# Altium schematic sheet 07 - BANK 34 SWITCHES, LEDs.SchDoc
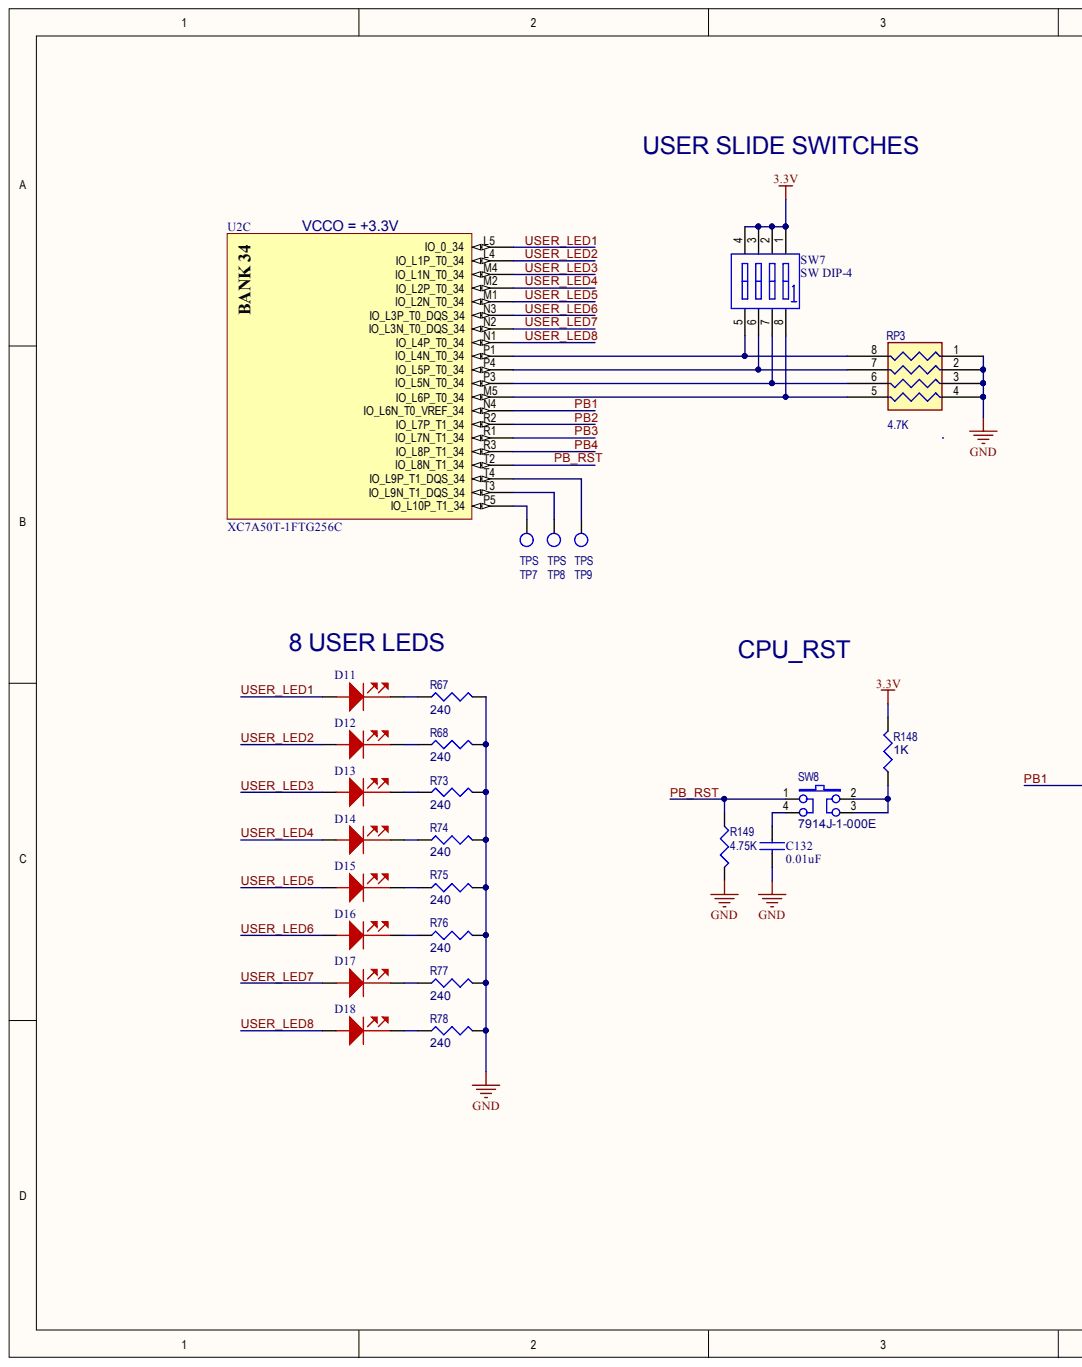
[diagram p0: 07 - BANK 34 SWITCHES, LEDs.SchDoc, left]
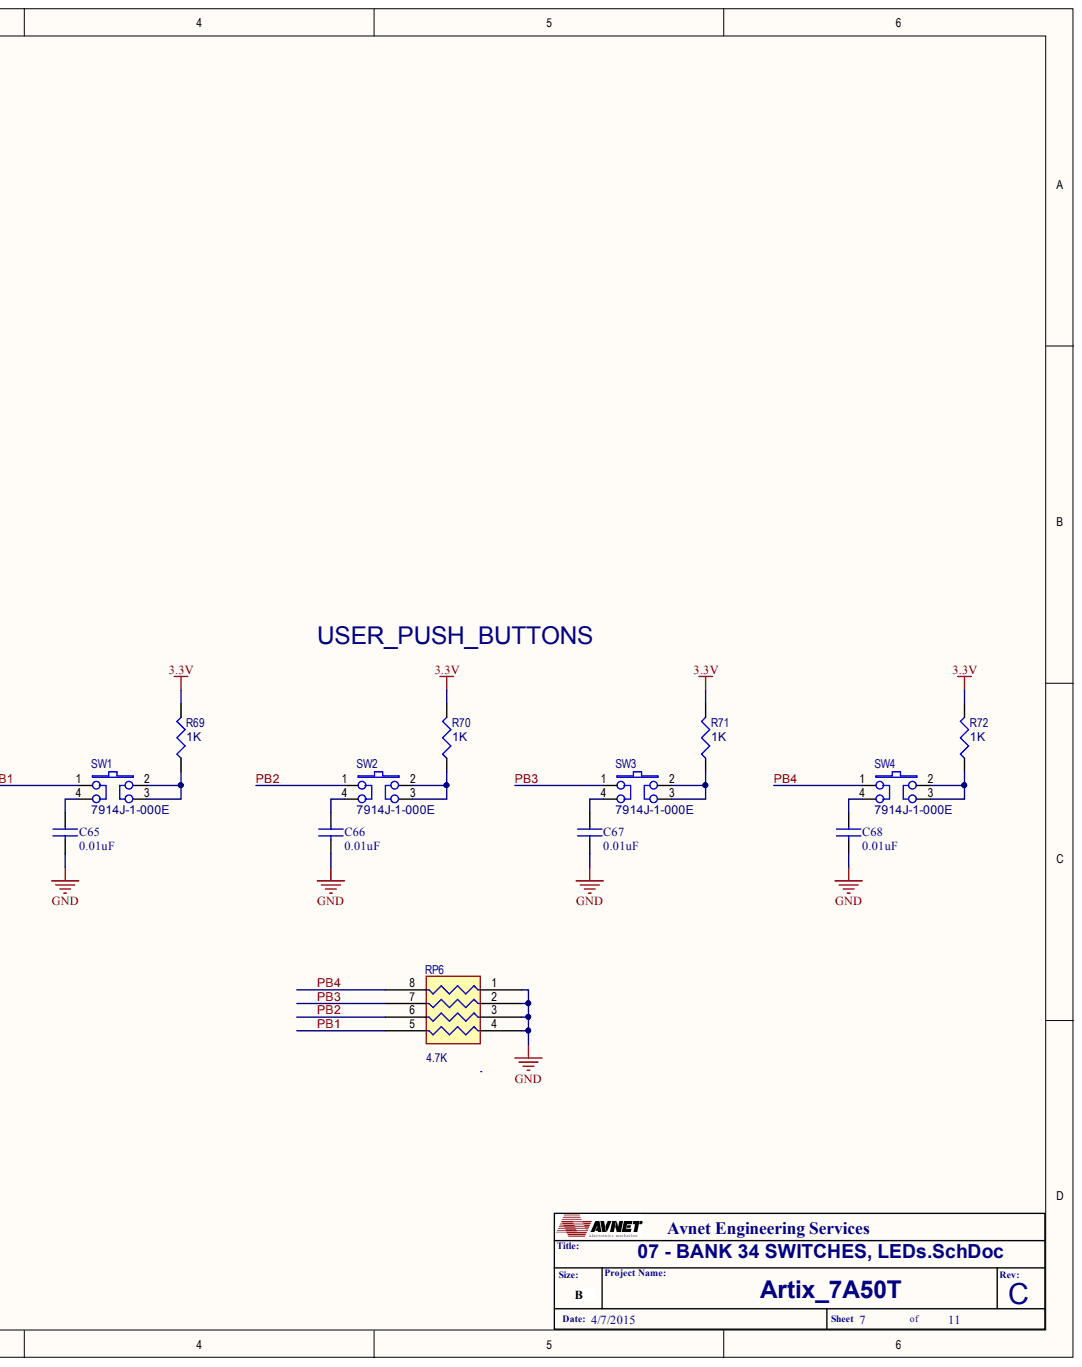
[diagram p1: 07 - BANK 34 SWITCHES, LEDs.SchDoc, right]

## Parts
C65  value 0.01uF  [C0201]  (hidden comment: PC003)
C66  value 0.01uF  [C0201]  (hidden comment: PC003)
C67  value 0.01uF  [C0201]  (hidden comment: PC003)
C68  value 0.01uF  [C0201]  (hidden comment: PC003)
C132  value 0.01uF  [C0201]  (hidden comment: PC003)
D11  DL015  [LED_LITE-LTST-C191KRKT]  (value not drawn)
D12  DL015  [LED_LITE-LTST-C191KRKT]  (value not drawn)
D13  DL015  [LED_LITE-LTST-C191KRKT]  (value not drawn)
D14  DL015  [LED_LITE-LTST-C191KRKT]  (value not drawn)
D15  DL015  [LED_LITE-LTST-C191KRKT]  (value not drawn)
D16  DL015  [LED_LITE-LTST-C191KRKT]  (value not drawn)
D17  DL015  [LED_LITE-LTST-C191KRKT]  (value not drawn)
D18  DL015  [LED_LITE-LTST-C191KRKT]  (value not drawn)
R67  240  [R0402]
R68  240  [R0402]
R69  1K  [R0402]
R70  1K  [R0402]
R71  1K  [R0402]
R72  1K  [R0402]
R73  240  [R0402]
R74  240  [R0402]
R75  240  [R0402]
R76  240  [R0402]
R77  240  [R0402]
R78  240  [R0402]
R148  1K  [R0402]
R149  value 4.75K  [R0402]  (hidden comment: 0402)
RP3  value 4.7K  [RPACK4-LCC]  (hidden comment: CAT16-472J4LF)
RP6  value 4.7K  [RPACK4-LCC]  (hidden comment: CAT16-472J4LF)
SW1  Device: 7914J-1-000E  [SW_BOU-7914]  (hidden comment: ES013)
SW2  Device: 7914J-1-000E  [SW_BOU-7914]  (hidden comment: ES013)
SW3  Device: 7914J-1-000E  [SW_BOU-7914]  (hidden comment: ES013)
SW4  Device: 7914J-1-000E  [SW_BOU-7914]  (hidden comment: ES013)
SW7  SW DIP-4  [DIPSW4]
SW8  Device: 7914J-1-000E  [SW_BOU-7914]  (hidden comment: ES013)
TP7  TPS  [TP24TH]
TP8  TPS  [TP24TH]
TP9  TPS  [TP24TH]
U2  MFGPN: XC7A50T-1FTG256C  [FTG256]  (hidden comment: XNLX-XC7A50T-XNLX-FTG256)  (part C)

## Nets
3.3V: R69.1 R70.1 R71.1 R72.1 R148.1 SW7.1 SW7.2 SW7.3 SW7.4
GND: C65.2 C66.2 C67.2 C68.2 C132.2 R67.2 R68.2 R73.2 R74.2 R75.2 R76.2 R77.2 R78.2 R149.2 RP3.1 RP3.2 RP3.3 RP3.4 RP6.1 RP6.2 RP6.3 RP6.4
PB1: RP6.5 SW1.1 U2.N4(IO_L6N_T0_VREF_34)
PB2: RP6.6 SW2.1 U2.R2(IO_L7P_T1_34)
PB3: RP6.7 SW3.1 U2.R1(IO_L7N_T1_34)
PB4: RP6.8 SW4.1 U2.R3(IO_L8P_T1_34)
PB_RST: R149.1 SW8.1 U2.T2(IO_L8N_T1_34)
USER_LED1: D11.A U2.L5(IO_0_34)
USER_LED2: D12.A U2.L4(IO_L1P_T0_34)
USER_LED3: D13.A U2.M4(IO_L1N_T0_34)
USER_LED4: D14.A U2.M2(IO_L2P_T0_34)
USER_LED5: D15.A U2.M1(IO_L2N_T0_34)
USER_LED6: D16.A U2.N3(IO_L3P_T0_DQS_34)
USER_LED7: D17.A U2.N2(IO_L3N_T0_DQS_34)
USER_LED8: D18.A U2.N1(IO_L4P_T0_34)
NetC65_1: C65.1 SW1.4
NetC66_1: C66.1 SW2.4
NetC67_1: C67.1 SW3.4
NetC68_1: C68.1 SW4.4
NetC132_1: C132.1 SW8.4
NetD11_C: D11.C R67.1
NetD12_C: D12.C R68.1
NetD13_C: D13.C R73.1
NetD14_C: D14.C R74.1
NetD15_C: D15.C R75.1
NetD16_C: D16.C R76.1
NetD17_C: D17.C R77.1
NetD18_C: D18.C R78.1
NetR69_2: R69.2 SW1.2 SW1.3
NetR70_2: R70.2 SW2.2 SW2.3
NetR71_2: R71.2 SW3.2 SW3.3
NetR72_2: R72.2 SW4.2 SW4.3
NetR148_2: R148.2 SW8.2 SW8.3
NetRP3_5: RP3.5 SW7.8 U2.M5(IO_L6P_T0_34)
NetRP3_6: RP3.6 SW7.7 U2.P3(IO_L5N_T0_34)
NetRP3_7: RP3.7 SW7.6 U2.P4(IO_L5P_T0_34)
NetRP3_8: RP3.8 SW7.5 U2.P1(IO_L4N_T0_34)
NetTP7_1: TP7.1 U2.P5(IO_L10P_T1_34)
NetTP8_1: TP8.1 U2.T3(IO_L9N_T1_DQS_34)
NetTP9_1: TP9.1 U2.T4(IO_L9P_T1_DQS_34)

## Library data of the parts
C65-C68 C132 = AVPC-0000003: CAP SM 0.01uF 10V 10% X5R C0201; PartCode: PC; Database Table Name: Passive-Cap$; MFG Code: AVX; MFGPN: 0201ZD103KAT2A; AsyTxt: PC003; AVLNo: AVPC-0000003; Component Kind: Standard; ComponentLink1Description: Datasheet; ComponentLink2Description: MFR Website; ComponentLink2URL: www.avx.com; Device: CAP; Dielectric: X5R; ESR: CAP; PkgType: SM; RoHS: Yes; Status: Preliminary; Tol: 10%; Volt: 10V; ComponentLink1URL: C:\AVDBLIB\DATASHEETS\AVX_CX5R.pdf; Library Name: Avnet_DBlib.DbLib
D11-D18 = AVDL-0000015: LED, Red, 631nm, Vf=2.0V, If=20mA, lv=54mcd; PartCode: DL; Database Table Name: Discrete-LED$; If: 20mA; LV: 54mcd; MFG Code: LTP; MFGPN: LTST-C191KRKT; PkgType: SM; Vf: 2.0V; AsyTxt: DL015; AVLNo: AVDL-0000015; Component Kind: Standard; ComponentLink1Description: Datasheet; Status: Preliminary; WaveLength: 631nM; ComponentLink1URL: C:\AVDBLIB\DATASHEETS\LTST-C191KRKT.pdf; Library Name: Avnet_DBlib.DbLib
R67 R68 R73-R78 = AVPR-0000511: RES SM 240 62mW 0.01 R0402; Alt1MFR: NIC; PartCode: PR; Alt1PN: NRC04F2400TRF; AsyTxt: PR511; Database Table Name: Passive-Res$; MFG Code: DAL; MFGPN: CRCW0402240RFKED; Power: 0; Tol: 0.01; Availability: 1M-AV; AVLNo: AVPR-0000511; Component Kind: Standard; ComponentLink1Description: Datasheet; Cost: .01; Device: RES; PkgType: SM; Received: 0; RoHS: Yes; Size: 1.9; Status: Preliminary; Value: 240; Watt: 62mW; ComponentLink1URL: C:\AVDBLIB\DATASHEETS\Dale_CRCW.pd; Library Name: Avnet_DBlib.DbLib
R69-R72 R148 = AVPR-0000052: RES SM 1K 62mW 0.01 R0402; PartCode: PR; AsyTxt: PR052; Database Table Name: Passive-Res$; MFG Code: DAL; MFGPN: CRCW04021K00FKED; Tol: 0.01; AVLNo: AVPR-0000052; Component Kind: Standard; ComponentLink1Description: Datasheet; Device: RES; PkgType: SM; RoHS: Yes; Status: Preliminary; Value: 1K; Watt: 62mW; ComponentLink1URL: C:\AVDBLIB\DATASHEETS\Dale_CRCW.pd; Library Name: Avnet_DBlib.DbLib
R149 = RESC 4K75 0402: Res Thick Film 0402 4.75K Ohm 1% 1/16W ±100ppm/°C Molded SMD SMD Paper T/R; MFG Code: DAL; MFGPN: CRCW04024K75FKED; Component Kind: Standard; Note: Res Thick Film 0402 4.75K Ohm 1% 1/16W ±100ppm/°C Molded SMD SMD Paper T/R; Signal Integrity: RES; Simulation: RES; Library Name: ZYNQ_Z7045_MITX_REVA.SCHLIB
RP3 RP6 = RESCAXE 4.7K: Res Thick Film Array 4.7K Ohm 5% ISOL Molded 8-Pin 1206 Concave SMD Paper T/R; MFG Code: BNS; Component Kind: Standard; MFGPN: CAT16-472J4LF; Note: Res Thick Film Array 4.7K Ohm 5% ISOL Molded 8-Pin 1206 Concave SMD Paper T/R; Library Name: ZYNQ_Z7045_MITX_REVA.SCHLIB
SW1-SW4 SW8 = AVES-0000013: Switch Momentary SM PB-SPST Push Button NO  1ch 16VDC 100mA  SW-PB-SPST SW_BOU-7914; PartCode: ES; Database Table Name: EM-Switch$; MFG Code: BNS; AsyTxt: ES013; AVLNo: AVES-0000013; Component Kind: Standard; ComponentLink1Description: Datasheet; Current: 100mA; Default: NO; Device Sub Type: PB-SPST; Device Type: Switch Momentary; MFGPN: 7914J-1-000E; PkgType: SM; Ports/Channels: 1ch; RoHS: Yes; Status: Preliminary; Technology: Push Button; Volts: 16VDC; ComponentLink1URL: C:\AVDBLIB\DATASHEETS\SW7914.pdf; Library Name: Avnet_DBlib.DbLib
SW7 = SW DIP-4: DIP Switch, 4 Position, SPST; MFG: TE; MFGPN: GDH04STR04; Code_IPC: SOIC127P600-8; Code_JEDEC: MS-012-AA; Component Kind: Standard; LatestRevisionDate: 29-May-2009; LatestRevisionNote: IPC-7351 Footprint Added.; Library Name: Miscellaneous Devices.IntLib; PackageDescription: 8-Pin Small Outline Integrated Circuit 1.27 mm Pitch; PackageReference: SO8; PackageVersion: Dec-2002; Published: 24-Mar-1999; Simulation: dpsw4; Publisher: Altium Limited
TP7-TP9 = AVPO-0000039: Test Point 24 mil pad, round, 10 mil hole, small symbol TPS TP24TH; MFG Code: None; Component Kind: Standard; DNP: DNP; MFGPN: None; Library Name: ZYNQ_Z7045_MITX_REVA.SCHLIB
U2 = XNLX-XC7A50T-XNLX-FTG256: PKG: FBGA256; Component Kind: Standard; Library Name: Artix_7A50_1.SCHLIB
